# Altium schematic sheet RL-17-003-STP-LR-Mainsheet.SchDoc
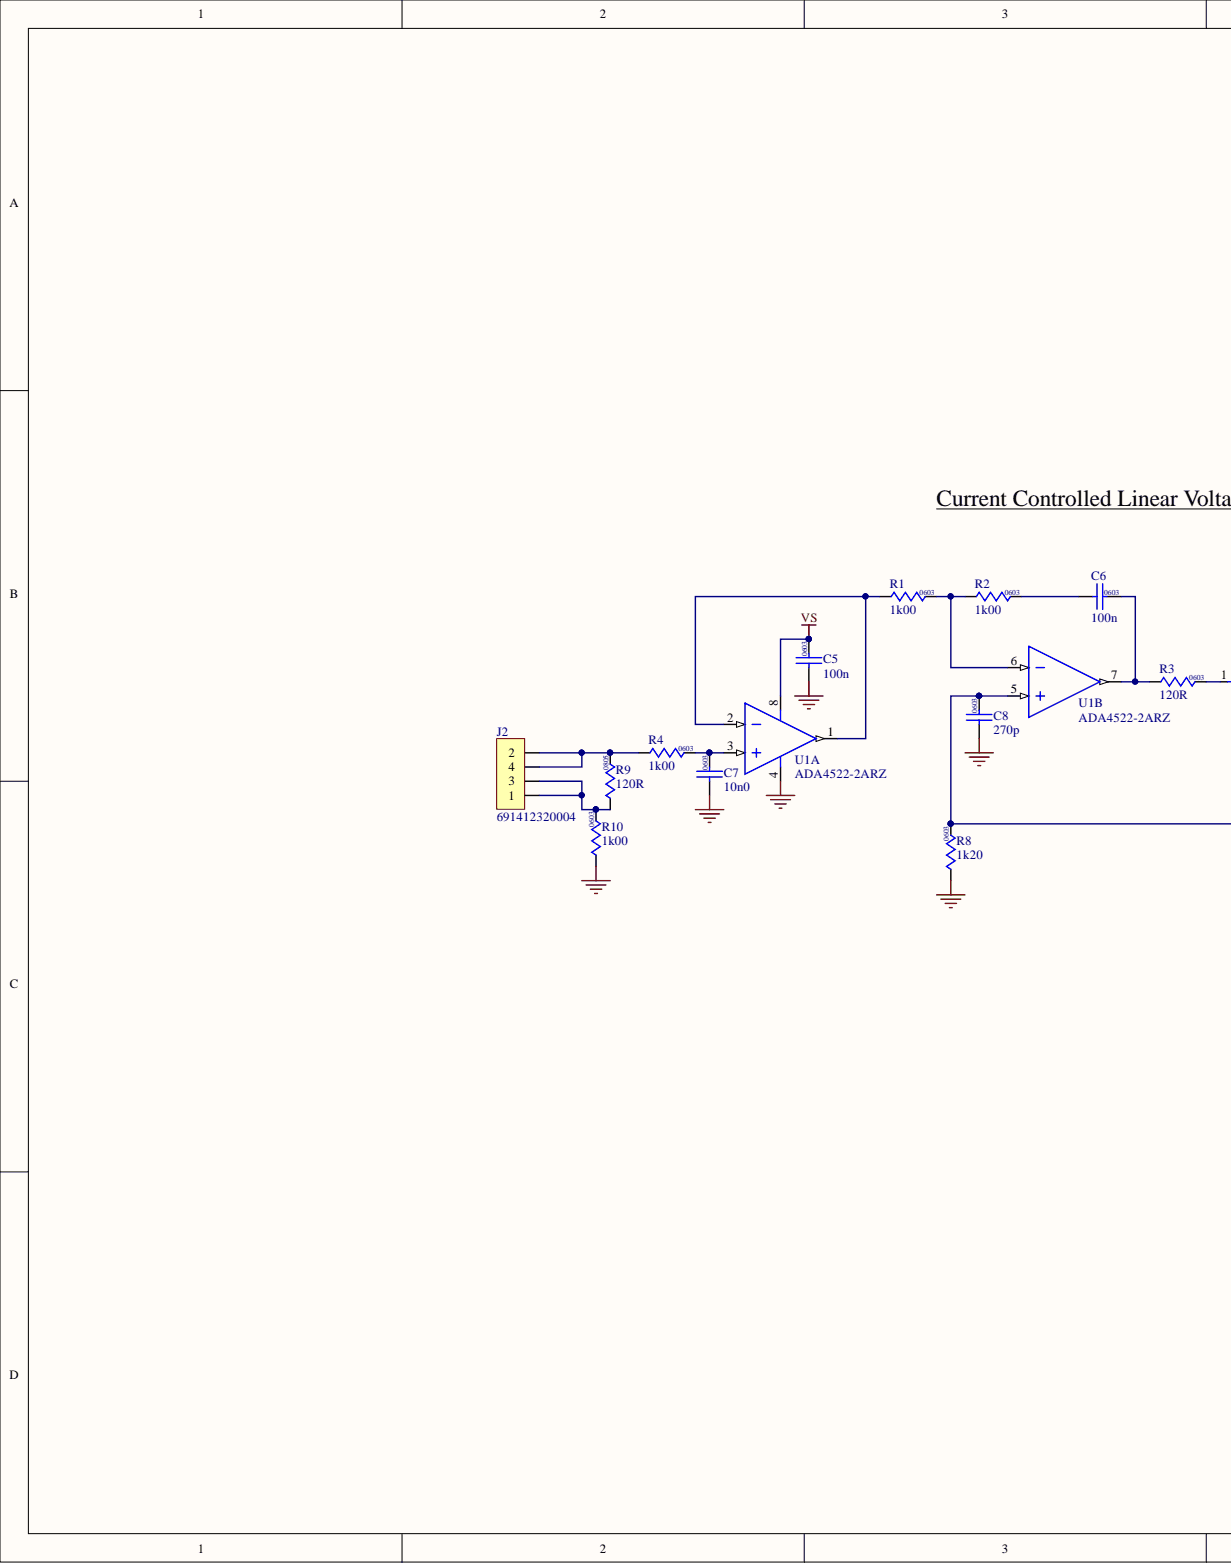
[diagram p0: RL-17-003-STP-LR-Mainsheet.SchDoc, left]
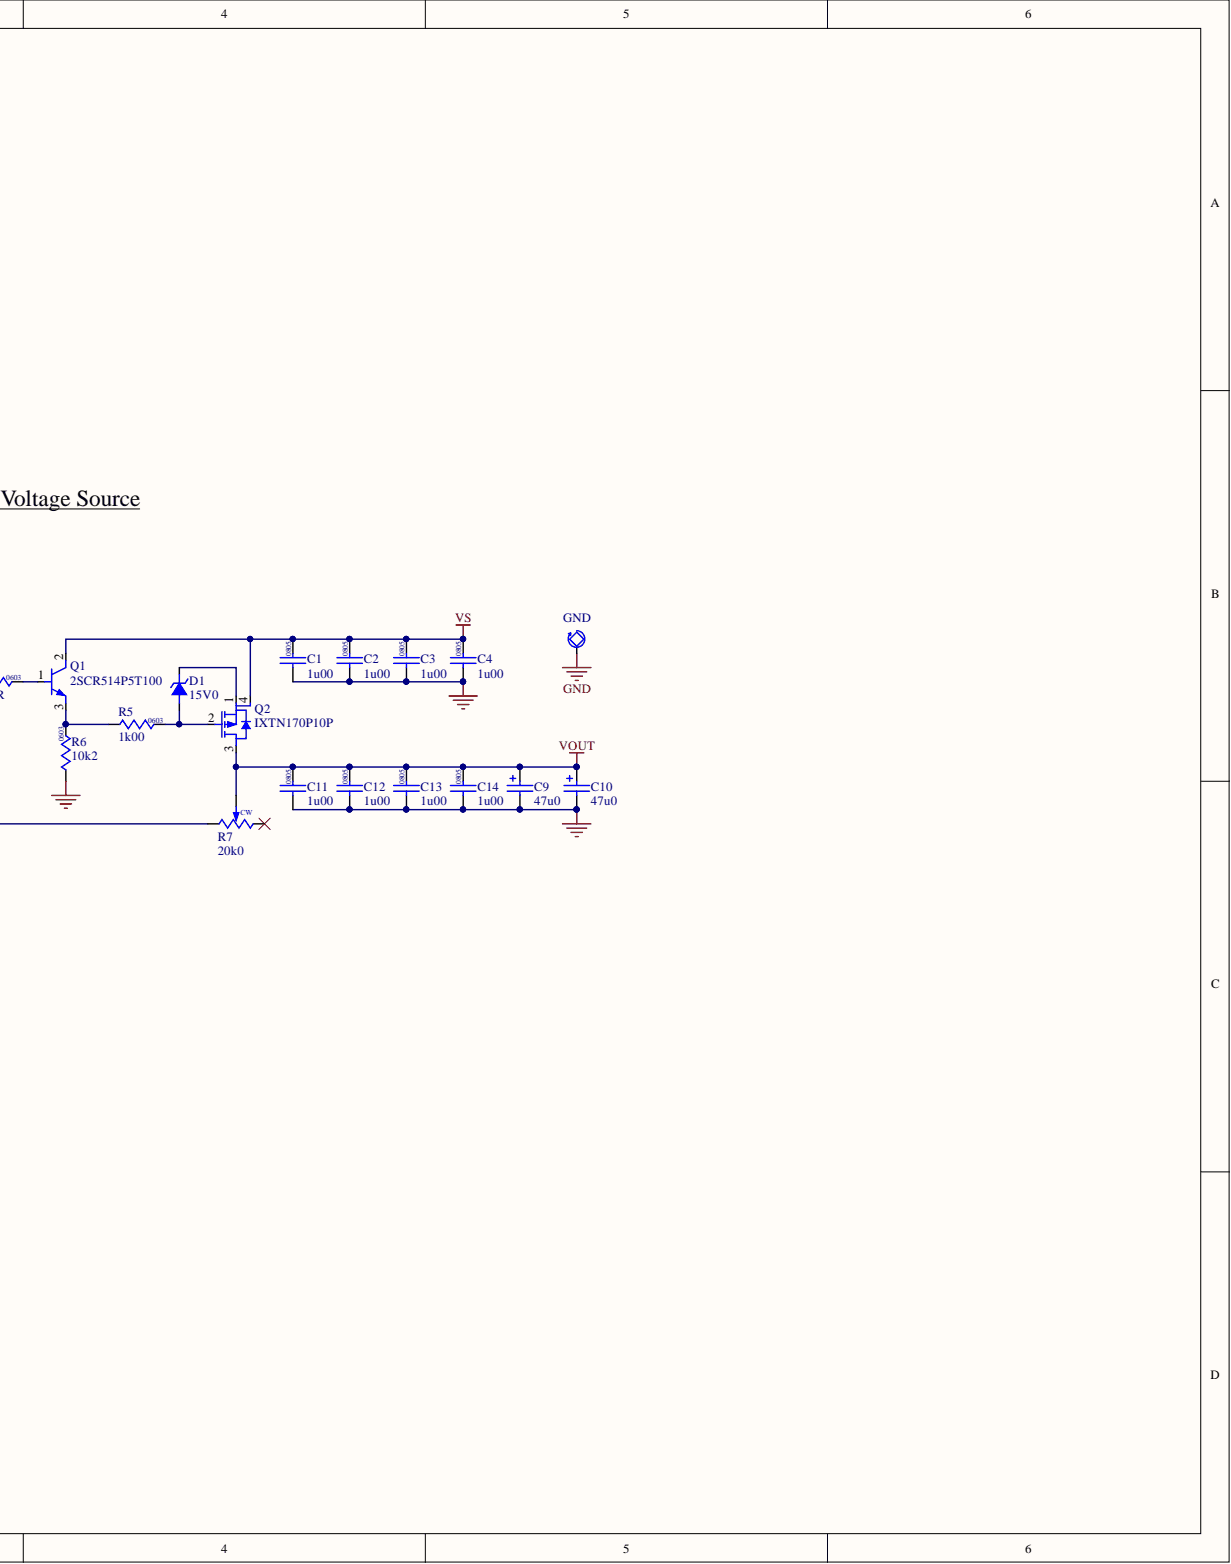
[diagram p1: RL-17-003-STP-LR-Mainsheet.SchDoc, right]

## Parts
C1  1u00  FPrint: 0805  [CAPC2012N]
C2  1u00  FPrint: 0805  [CAPC2012N]
C3  1u00  FPrint: 0805  [CAPC2012N]
C4  1u00  FPrint: 0805  [CAPC2012N]
C5  100n  FPrint: 0603  [CAPC1608N]
C6  100n  FPrint: 0603  [CAPC1608N]
C7  10n0  FPrint: 0603  [CAPC1608N]
C8  270p  FPrint: 0603  [CAPC1608N]
C9  47u0  [CAPAE135135X135N]
C10  47u0  [CAPAE135135X135N]
C11  1u00  FPrint: 0805  [CAPC2012N]
C12  1u00  FPrint: 0805  [CAPC2012N]
C13  1u00  FPrint: 0805  [CAPC2012N]
C14  1u00  FPrint: 0805  [CAPC2012N]
D1  Voltage: 15V0  [SODFL350X120-2N]  (hidden comment: PTVS15VS1UTR,115)
J1  GND  [CON-WE-7466004]
J2  691412320004  [CON-WE-691412320004]
Q1  2SCR514P5T100  [SOT89-150P400X150-3N]
Q2  IXTN170P10P  [PM-SOT-227-4]
R1  1k00  FPrint: 0603  [RESC1608N]
R2  1k00  FPrint: 0603  [RESC1608N]
R3  120R  FPrint: 0603  [RESC1608N]
R4  1k00  FPrint: 0603  [RESC1608N]
R5  1k00  FPrint: 0603  [RESC1608N]
R6  10k2  FPrint: 0603  [RESC1608N]
R7  20k0  [RES-POT-TT-64W]
R8  1k20  FPrint: 0603  [RESC1608N]
R9  120R  FPrint: 0805  [RESC2012N]
R10  1k00  FPrint: 0603  [RESC1608N]
U1  ADA4522-2ARZ  [SOIC127P600X175-8N]  (parts A,B)

## Nets
GND: C1.2 C2.2 C3.2 C4.2 C5.2 C7.2 C8.2 C9.2 C10.2 C11.2 C12.2 C13.2 C14.2 J1.1 R6.1 R8.1 R10.1 U1.4
VOUT: C9.1 C10.1 C11.1 C12.1 C13.1 C14.1 Q2.3 R7.2
VS: C1.1 C2.1 C3.1 C4.1 C5.1 Q1.2 Q2.4 U1.8
NetC6_1: C6.1 R3.1 U1.7
NetC6_2: C6.2 R2.2
NetC7_1: C7.1 R4.2 U1.3
NetC8_1: C8.1 R7.1 R8.2 U1.5
NetD1_A: D1.A Q2.2 R5.2
NetD1_C: D1.C Q2.1
NetJ2_1: J2.1 J2.3 R9.1 R10.2
NetJ2_2: J2.2 J2.4 R4.1 R9.2
NetQ1_1: Q1.1 R3.2
NetQ1_3: Q1.3 R5.1 R6.2
NetR1_1: R1.1 U1.1 U1.2
NetR1_2: R1.2 R2.1 U1.6

Not connected: R7 3

## Library data of the parts
C1-C4 C11-C14 = C-0805-2012: Capacitor, SMD, Case 0805 (2012 Metric); Manufacturer: Taiyo Yuden; Manufacturer Part Number: TMK212B7105KG-T; Class1: Passive; Tolerance: 10%; Type: X7R; Voltage: 25V; Class2: Capacitor; Component Kind: Standard; Published: 08JAN17; Supplier 1: Mouser; Supplier 1 Part Number: 963-TMK212B7105KG-T; Supplier 2: Digikey; Value: 1u00; Library Name: Rafael.SchLib; Publisher: Rafael
C5 C6 = C-0603-1608: Capacitor, SMD, Case 0603 (1608 Metric); Manufacturer: Murata; Manufacturer Part Number: GRM188R72A104KA35D; Class1: Passive; Tolerance: 10%; Type: X7R; Voltage: 100V; Class2: Capacitor; Component Kind: Standard; Published: 08JAN17; Supplier 1: Mouser; Supplier 1 Part Number: 81-GRM188R72A104KA35; Supplier 2: Digikey; Value: 100n; Library Name: Rafael.SchLib; Publisher: Rafael
C7 = C-0603-1608: Capacitor, SMD, Case 0603 (1608 Metric); Manufacturer: Murata; Manufacturer Part Number: GRJ188R72A103KE11D; Class1: Passive; Tolerance: 10%; Type: X7R; Voltage: 100V; Class2: Capacitor; Component Kind: Standard; Published: 08JAN17; Supplier 1: Mouser; Supplier 1 Part Number: 81-GRJ188R72A103KE1D; Supplier 2: Digikey; Value: 10n0; Library Name: Rafael.SchLib; Publisher: Rafael
C8 = C-0603-1608: Capacitor, SMD, Case 0603 (1608 Metric); Manufacturer: Murata; Manufacturer Part Number: GRM188R72A271KA01D; Class1: Passive; Tolerance: 10%; Type: X7R; Voltage: 100V; Class2: Capacitor; Component Kind: Standard; Published: 08JAN17; Supplier 1: Mouser; Supplier 1 Part Number: 81-GRM188R72A271KA1D; Supplier 2: Digikey; Value: 270p; Library Name: Rafael.SchLib; Publisher: Rafael
C9 C10 = C-AE-H13: Aluminum Electrolytic Capacitor, SMD, Case H13, Height 13.50mm, Can Dia 12.5mm, Footprint 13.50x13.50mm; Manufacturer: Panasonic; Manufacturer Part Number: EEV-TG2A470Q; Class1: Passive; Tolerance: 20%; Type: Aluminum Electrolytic Capacitors; Voltage: 100V; Class2: Capacitor; Component Kind: Standard; Published: 10DEC17; Supplier 1: Mouser; Supplier 1 Part Number: 667-EEV-TG2A470Q; Supplier 2: Digikey; Value: 47u0; Library Name: Rafael.SchLib; Publisher: Rafael
D1 = D-TVS-SOD123: Unidirectional TVS Diode, SOD123 Package; Manufacturer: NXP; Class1: Passive; Class2: Diode; Component Kind: Standard; FPrint: SOD123; Manufacturer Part Number: PTVS15VS1UTR,115; Published: 24JAN17; Supplier 1: Mouser; Supplier 1 Part Number: 771-PTVS15VS1UTR115; Supplier 2: Digikey; Type: TVS; Library Name: Rafael.SchLib; Publisher: Rafael
J1 = TER-WE-WP-SMBU: Terminal, Screw Connector, SMD, Internal Thread; Manufacturer: Würth Elektronik; Manufacturer Part Number: 7466004; Class1: Passive; Class2: Terminal; Component Kind: Standard; Published: 27JAN17; Supplier 1: Mouser; Supplier 1 Part Number: 710-7466004; Supplier 2: Digikey; Library Name: Rafael.SchLib; Publisher: Rafael
J2 = TB-WE-691412320004: Terminal Block, 4 Pos, 1 Row, TH, Pitch 3.81mm, 45° Cable Entry, 16~30 AWG, Screwless, Push Button; Manufacturer: Würth Elektronik; Class1: Passive; Class2: Terminal Block; Component Kind: Standard; Manufacturer Part Number: 691412320004; Published: 02SEP17; Supplier 1: Mouser; Supplier 2: Digikey; Library Name: Rafael.SchLib; Publisher: Rafael
Q1 = BJT-2SCR514P5T100: NPN, General Purpose, Low Saturation, ft 320MHz, Vce 80V, Rsat 1Ω, Ic 0.7A; Manufacturer: Rohm Semi; Class1: Active; Class2: NPN; Component Kind: Standard; Manufacturer Part Number: 2SCR514P5T100; Published: 10DEC17; Supplier 1: Mouser; Supplier 2: Digikey; Library Name: Rafael.SchLib; Publisher: Rafael
Q2 = FET-IXTN170P10P: P-MOSFET, Power, Vds -100V, Vgs ±20V, Id -170A (Tc), Pd 890W (Tc), Vgsth -2V~-4V, Chasis Mount, Avalanche Rated, Fast Intrinsic Diode; Manufacturer: IXYS; Class1: Active; Class2: P-MOSFET; Component Kind: Standard; Manufacturer Part Number: IXTN170P10P; Published: 25JAN17; Supplier 1: Mouser; Supplier 2: Digikey; Library Name: Rafael.SchLib; Publisher: Rafael
R1 R2 R4 R5 R10 = R-0603-1608: Resistor, SMD, Case 0603 (1608 Metric); Manufacturer: Yageo; Manufacturer Part Number: RC0603FR-071KL; Class1: Passive; Tolerance: 1%; Voltage: 75V; Class2: Resistor; Component Kind: Standard; Published: 08JAN17; Supplier 1: Mouser; Supplier 1 Part Number: 603-RC0603FR-071KL; Supplier 2: Digikey; Type: Thick Film; Value: 1k00; Library Name: Rafael.SchLib; Publisher: Rafael
R3 = R-0603-1608: Resistor, SMD, Case 0603 (1608 Metric); Manufacturer: Yageo; Manufacturer Part Number: RC0603FR-07120RL; Class1: Passive; Tolerance: 1%; Voltage: 75V; Class2: Resistor; Component Kind: Standard; Published: 08JAN17; Supplier 1: Mouser; Supplier 1 Part Number: 603-RC0603FR-07120RL; Supplier 2: Digikey; Type: Thick Film; Value: 120R; Library Name: Rafael.SchLib; Publisher: Rafael
R6 = R-0603-1608: Resistor, SMD, Case 0603 (1608 Metric); Manufacturer: Yageo; Manufacturer Part Number: RC0603FR-0710K2L; Class1: Passive; Tolerance: 1%; Voltage: 75V; Class2: Resistor; Component Kind: Standard; Published: 08JAN17; Supplier 1: Mouser; Supplier 1 Part Number: 603-RC0603FR-0710K2L; Supplier 2: Digikey; Type: Thick Film; Value: 10k2; Library Name: Rafael.SchLib; Publisher: Rafael
R7 = R-POT-TT-64W: Trimmer Potentiometer, Multiturn, Through Hole, Top Adjustment, Size 6.35x4.19mm, TT Electronics 64W Series; Manufacturer Part Number: PN?; Class1: Passive; Tolerance: ?%; Voltage: ?V; Class2: Trimmer Potentiometer; Component Kind: Standard; Manufacturer: TT Electronics; Published: 15JAN17; Supplier 1: Mouser; Supplier 2: Digikey; Value: 20k0; Library Name: Rafael.SchLib; Publisher: Rafael
R8 = R-0603-1608: Resistor, SMD, Case 0603 (1608 Metric); Manufacturer: Yageo; Manufacturer Part Number: RC0603FR-071K2L; Class1: Passive; Tolerance: 1%; Voltage: 75V; Class2: Resistor; Component Kind: Standard; Published: 08JAN17; Supplier 1: Mouser; Supplier 1 Part Number: 603-RC0603FR-071K2L; Supplier 2: Digikey; Type: Thick Film; Value: 1k20; Library Name: Rafael.SchLib; Publisher: Rafael
R9 = R-0805-2012: Resistor, SMD, Case 0805 (2012 Metric); Manufacturer: Susumu; Manufacturer Part Number: RG2012N-121-W-T1; Class1: Passive; Tolerance: 0.05%; Voltage: 150V; Class2: Resistor; Component Kind: Standard; Published: 08JAN17; Supplier 1: Mouser; Supplier 1 Part Number: 754-RG2012N-121-W-T1; Supplier 2: Digikey; Type: Thin Film; Value: 120R; Library Name: Rafael.SchLib; Publisher: Rafael
U1 = AMP-ADA4522-2ARZ: Operational Amplifier, Dual, 4.5V~55V Supply, 1.7mA Quiescent Current, RRO, 1.5uV Input Offset, 6nV/°C Offset Drift, 5.8nV/√Hz Noise Density at 1kHz, 144dB CMRR, 160dB PSRR, 2.7MHz GBP; Manufacturer: Analog Devices; Class1: Active; Class2: OpAmp; Component Kind: Standard; Manufacturer Part Number: ADA4522-2ARZ; Published: 14JAN17; Supplier 1: Mouser; Supplier 2: Digikey; Library Name: Rafael.SchLib; Publisher: Rafael
